# Altium schematic sheet overview.SchDoc
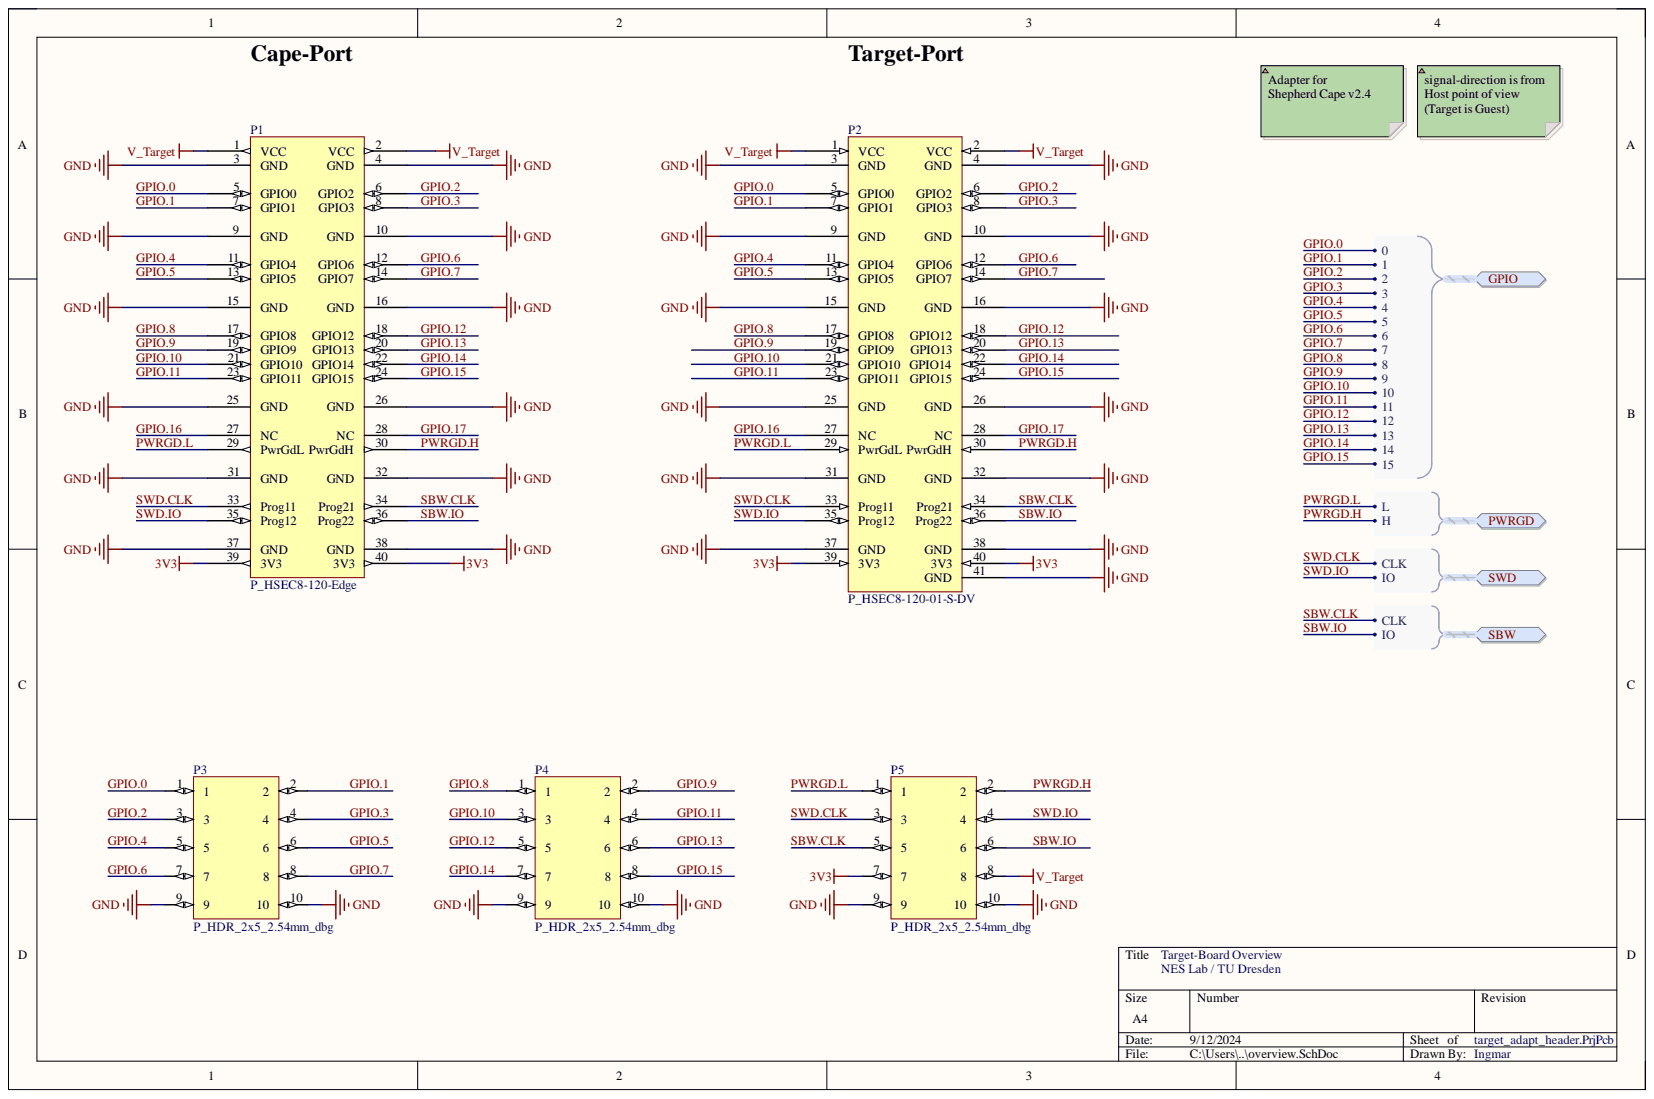
[diagram p0: overview.SchDoc]

## Parts
P1  P_HSEC8-120-Edge  [P_HSEC8-120_edge]
P2  P_HSEC8-120-01-S-DV  [P_HSEC8-120-01-S-DV]
P3  P_HDR_2x5_2.54mm_dbg  [P_Pinheader_2x5_R2.54mm_pressfit]
P4  P_HDR_2x5_2.54mm_dbg  [P_Pinheader_2x5_R2.54mm_pressfit]
P5  P_HDR_2x5_2.54mm_dbg  [P_Pinheader_2x5_R2.54mm_pressfit]

## Ports
GPIO, GPIO.0, GPIO.1, GPIO.2, GPIO.3, GPIO.4, GPIO.5, GPIO.6, GPIO.7, GPIO.8, GPIO.9, GPIO.10, GPIO.11, GPIO.12, GPIO.13, GPIO.14, GPIO.15, PWRGD, PWRGD.H, PWRGD.L, SBW, SBW.CLK, SBW.IO, SWD, SWD.CLK, SWD.IO

## Nets
3V3: P1.39(3V3) P1.40(3V3) P2.39(3V3) P2.40(3V3) P5.7
GND: P1.3(GND) P1.4(GND) P1.9(GND) P1.10(GND) P1.15(GND) P1.16(GND) P1.25(GND) P1.26(GND) P1.31(GND) P1.32(GND) P1.37(GND) P1.38(GND) P2.3(GND) P2.4(GND) P2.9(GND) P2.10(GND) P2.15(GND) P2.16(GND) P2.25(GND) P2.26(GND) P2.31(GND) P2.32(GND) P2.37(GND) P2.38(GND) P2.41(GND) P3.9 P3.10 P4.9 P4.10 P5.9 P5.10
V_Target: P1.1(VCC) P1.2(VCC) P2.1(VCC) P2.2(VCC) P5.8
GPIO.0: P1.5(GPIO0) P2.5(GPIO0) P3.1 ; port GPIO
GPIO.1: P1.7(GPIO1) P1.21(GPIO10) P2.7(GPIO1) P2.21(GPIO10) P3.2 P4.3 ; port GPIO  [also labelled GPIO.10]
GPIO.2: P1.6(GPIO2) P2.6(GPIO2) P3.3 ; port GPIO
GPIO.3: P1.8(GPIO3) P2.8(GPIO3) P3.4 ; port GPIO
GPIO.4: P1.11(GPIO4) P2.11(GPIO4) P3.5 ; port GPIO
GPIO.5: P1.13(GPIO5) P2.13(GPIO5) P3.6 ; port GPIO
GPIO.6: P1.12(GPIO6) P2.12(GPIO6) P3.7 ; port GPIO
GPIO.7: P1.14(GPIO7) P2.14(GPIO7) P3.8 ; port GPIO
GPIO.8: P1.17(GPIO8) P2.17(GPIO8) P4.1 ; port GPIO
GPIO.9: P1.19(GPIO9) P2.19(GPIO9) P4.2 ; port GPIO
GPIO.11: P1.23(GPIO11) P2.23(GPIO11) P4.4 ; port GPIO
GPIO.12: P1.18(GPIO12) P2.18(GPIO12) P4.5 ; port GPIO
GPIO.13: P1.20(GPIO13) P2.20(GPIO13) P4.6 ; port GPIO
GPIO.14: P1.22(GPIO14) P2.22(GPIO14) P4.7 ; port GPIO
GPIO.15: P1.24(GPIO15) P2.24(GPIO15) P4.8 ; port GPIO
GPIO.16: P1.27(NC) P2.27(NC)
GPIO.17: P1.28(NC) P2.28(NC)
PWRGD.H: P1.30(PwrGdH) P2.30(PwrGdH) P5.2 ; port PWRGD
PWRGD.L: P1.29(PwrGdL) P2.29(PwrGdL) P5.1 ; port PWRGD
SBW.CLK: P1.34(Prog21) P2.34(Prog21) P5.5 ; port SBW
SBW.IO: P1.36(Prog22) P2.36(Prog22) P5.6 ; port SBW
SWD.CLK: P1.33(Prog11) P2.33(Prog11) P5.3 ; port SWD
SWD.IO: P1.35(Prog12) P2.35(Prog12) P5.4 ; port SWD

## Library data of the parts
P1 = .P_HSEC8-120-Edge: Gold, Vertical, EdgeConnector, 2 Row, .8mm distance; Manufacturer: Samtec; I_max: 2.8A; V_max: 240V; Component Kind: Standard; Distributer: Mouser; Library Name: shepherd_parts.SchLib
P2 = .P_HSEC8-120-01-S-DV: Gold, Vertical, EdgeConnector, 2 Row, .8mm distance; Manufacturer: Samtec; Manufacturer PN: HSEC8-120-01-L-DV-A-K-TR; I_max: 2.8A; V_max: 240V; Component Kind: Standard; Distributer: Mouser; Distributer PN: 200-HSEC812001LDVAKT; Price n10 [€]: 3.17; Library Name: shepherd_parts.SchLib
P3-P5 = .P_HDR_2x5_2.54mm: Gold, Straight; Component Kind: Standard; Distributer: Mouser; Library Name: shepherd_parts.SchLib
